# Altium schematic sheet Supervisor.SchDoc
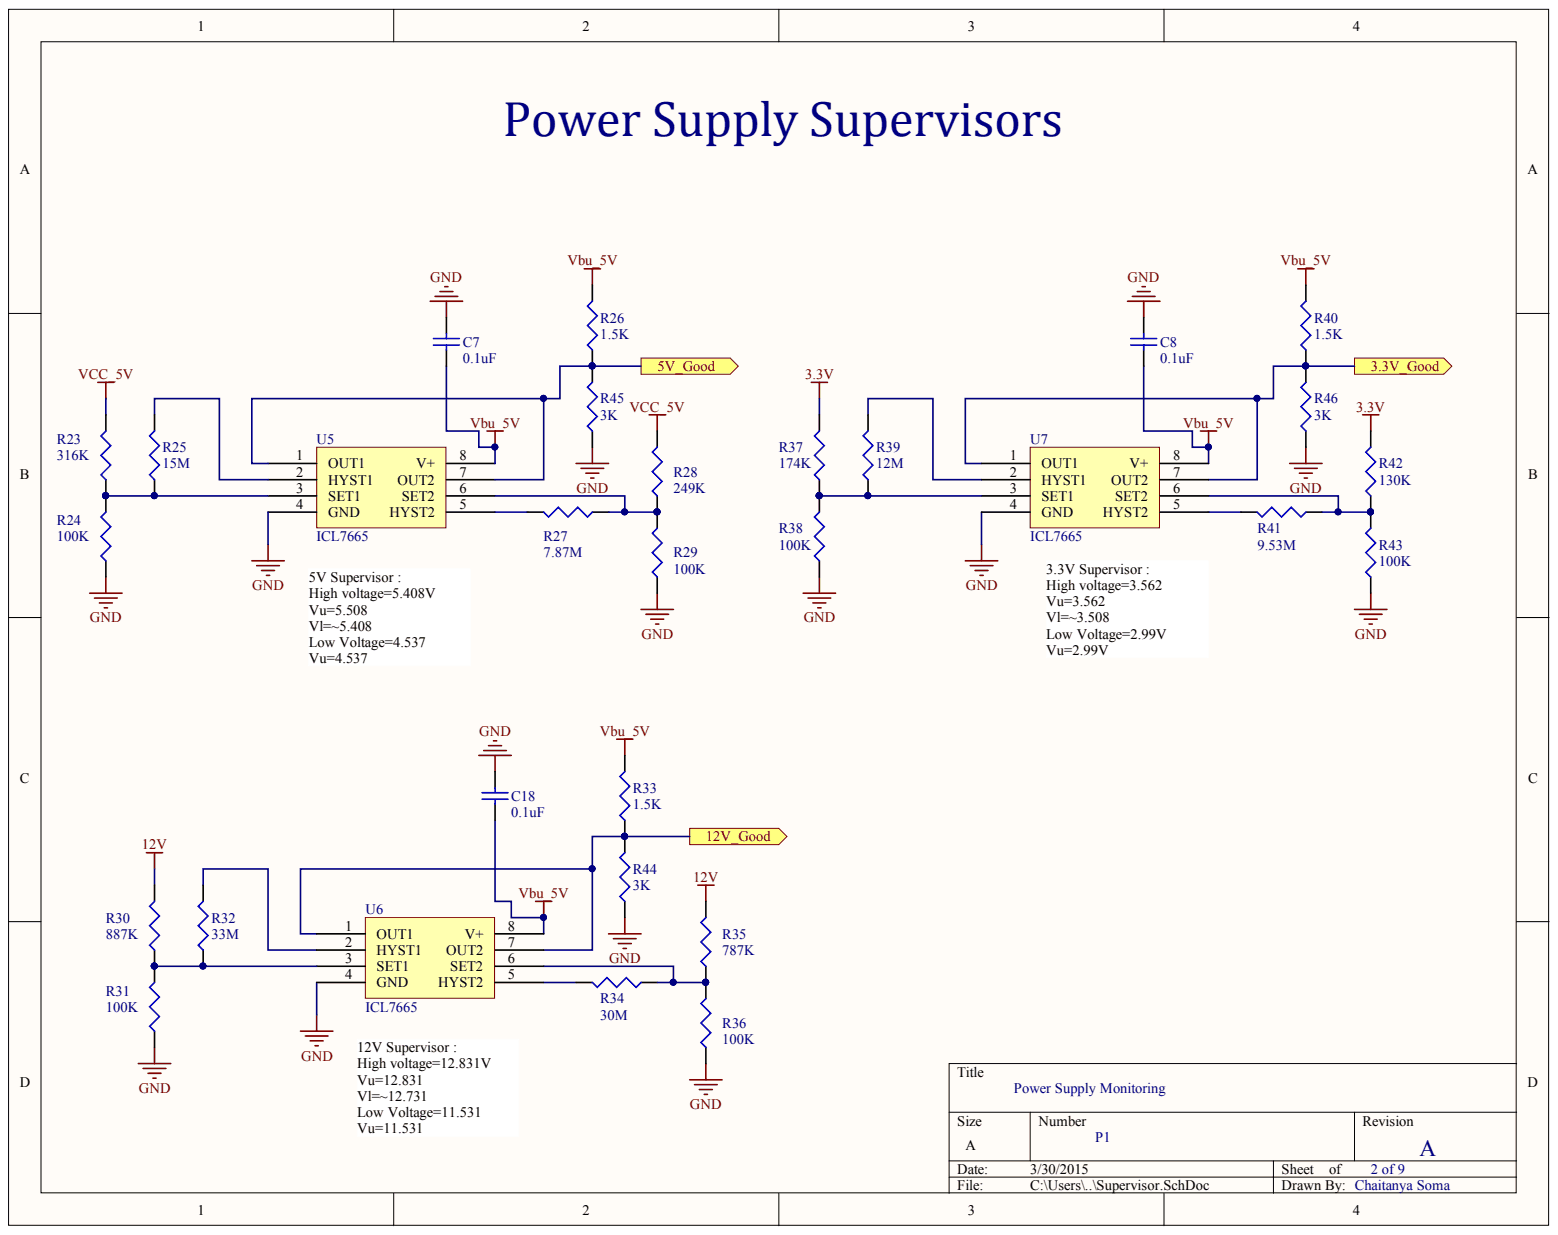
[diagram p0: Supervisor.SchDoc]

## Parts
C7  value 0.1uF  [1608[0603]]  (hidden comment: Cap Semi)
C8  value 0.1uF  [1608[0603]]  (hidden comment: Cap Semi)
C18  value 0.1uF  [1608[0603]]  (hidden comment: Cap Semi)
R23  value 316K  [J1-0603]  (hidden comment: Res3)
R24  value 100K  [J1-0603]  (hidden comment: Res3)
R25  value 15M  [J1-0603]  (hidden comment: Res3)
R26  value 1.5K  [J1-0603]  (hidden comment: Res3)
R27  value 7.87M  [J1-0603]  (hidden comment: Res3)
R28  value 249K  [J1-0603]  (hidden comment: Res3)
R29  value 100K  [J1-0603]  (hidden comment: Res3)
R30  value 887K  [J1-0603]  (hidden comment: Res3)
R31  value 100K  [J1-0603]  (hidden comment: Res3)
R32  value 33M  [J1-0603]  (hidden comment: Res3)
R33  value 1.5K  [J1-0603]  (hidden comment: Res3)
R34  value 30M  [J1-0603]  (hidden comment: Res3)
R35  value 787K  [J1-0603]  (hidden comment: Res3)
R36  value 100K  [J1-0603]  (hidden comment: Res3)
R37  value 174K  [J1-0603]  (hidden comment: Res3)
R38  value 100K  [J1-0603]  (hidden comment: Res3)
R39  value 12M  [J1-0603]  (hidden comment: Res3)
R40  value 1.5K  [J1-0603]  (hidden comment: Res3)
R41  value 9.53M  [J1-0603]  (hidden comment: Res3)
R42  value 130K  [J1-0603]  (hidden comment: Res3)
R43  value 100K  [J1-0603]  (hidden comment: Res3)
R44  value 3K  [J1-0603]  (hidden comment: Res3)
R45  value 3K  [J1-0603]  (hidden comment: Res3)
R46  value 3K  [J1-0603]  (hidden comment: Res3)
U5  ICL7665  [ICL7665ACSA+T]
U6  ICL7665  [ICL7665ACSA+T]
U7  ICL7665  [ICL7665ACSA+T]

## Ports
3.3V_GOOD, 5V_GOOD, 12V_GOOD

## Nets
3.3V: R37.2 R42.2
12V: R30.2 R35.2
GND: C7.1 C8.1 C18.1 R24.1 R29.1 R31.1 R36.1 R38.1 R43.1 R44.1 R45.1 R46.1 U5.4(GND) U6.4(GND) U7.4(GND)
VBU_5V: C7.2 C8.2 C18.2 R26.2 R33.2 R40.2 U5.8(V+) U6.8(V+) U7.8(V+)
VCC_5V: R23.2 R28.2
NetR23_1: R23.1 R24.2 R25.1 U5.3(SET1)
NetR25_2: R25.2 U5.2(HYST1)
NetR26_1: R26.1 R45.2 U5.1(OUT1) U5.7(OUT2) ; port 5V_GOOD
NetR27_1: R27.1 R28.1 R29.2 U5.6(SET2)
NetR27_2: R27.2 U5.5(HYST2)
NetR30_1: R30.1 R31.2 R32.1 U6.3(SET1)
NetR32_2: R32.2 U6.2(HYST1)
NetR33_1: R33.1 R44.2 U6.1(OUT1) U6.7(OUT2) ; port 12V_GOOD
NetR34_1: R34.1 R35.1 R36.2 U6.6(SET2)
NetR34_2: R34.2 U6.5(HYST2)
NetR37_1: R37.1 R38.2 R39.1 U7.3(SET1)
NetR39_2: R39.2 U7.2(HYST1)
NetR40_1: R40.1 R46.2 U7.1(OUT1) U7.7(OUT2) ; port 3.3V_GOOD
NetR41_1: R41.1 R42.1 R43.2 U7.6(SET2)
NetR41_2: R41.2 U7.5(HYST2)

## Library data of the parts
C7 C8 C18 = Cap Semi: Capacitor (Semiconductor SIM Model); Component Cost: 0.10000; Component Link Descriptions: CAP CER 0.1UF 25V 10% X7R 0603; Component Kind: Standard; Component Link URL: http://www.digikey.com/product-detail/en/GRM188R71E104KA01D/490-1524-1-ND/587865; Digikey PN: 490-1524-1-ND; LatestRevisionDate: 29-May-2009; LatestRevisionNote: IPC-7351 Footprint Added.; Library Name: Miscellaneous Devices.IntLib; PackageDescription: Chip Capacitor; PackageReference: C1206; Published: 8-Jun-2000; Signal Integrity: Cap Semi; Simulation: CAP; Publisher: Altium Limited
R23 = Res3: Resistor; Component Cost: 0.10000; Component Link Descriptions: RES SMD 316K OHM 1% 1/10W 0603; Component Kind: Standard; Component Link URL: http://www.digikey.com/product-detail/en/ERJ-3EKF3163V/P316KHCT-ND/198329; Digikey PN: P316KHCT-ND; LatestRevisionDate: 29-May-2009; LatestRevisionNote: IPC-7351 Footprint Added.; Library Name: Miscellaneous Devices.IntLib; PackageDescription: Chip Resistor; PackageReference: J1-0603; Published: 8-Jun-2000; Signal Integrity: Res; Simulation: RESISTOR; Publisher: Altium Limited
R24 R29 R31 R36 R38 R43 = Res3: Resistor; Component Cost: 0.10000; Component Link Descriptions: RES SMD 100K OHM 1% 1/10W 0603; Component Kind: Standard; Component Link URL: http://www.digikey.com/product-detail/en/ERJ-3EKF1003V/P100KHCT-ND/198110; Digikey PN: P100KHCT-ND; LatestRevisionDate: 29-May-2009; LatestRevisionNote: IPC-7351 Footprint Added.; Library Name: Miscellaneous Devices.IntLib; PackageDescription: Chip Resistor; PackageReference: J1-0603; Published: 8-Jun-2000; Signal Integrity: Res; Simulation: RESISTOR; Publisher: Altium Limited
R25 = Res3: Resistor; Component Cost: 0.41300; Component Link Descriptions: RES SMD 15M OHM 5% 1/10W 0603; Component Kind: Standard; Component Link URL: http://www.digikey.com/product-detail/en/CRCW060315M0JPEAHR/541-15MAHCT-ND/3231943; Digikey PN: 541-15MAHCT-ND; LatestRevisionDate: 29-May-2009; LatestRevisionNote: IPC-7351 Footprint Added.; Library Name: Miscellaneous Devices.IntLib; PackageDescription: Chip Resistor; PackageReference: J1-0603; Published: 8-Jun-2000; Signal Integrity: Res; Simulation: RESISTOR; Publisher: Altium Limited
R26 R33 R40 = Res3: Resistor; Component Cost: 0.10000; Component Link Descriptions: RES SMD 1.5K OHM 1% 1/10W 0603; Component Kind: Standard; Component Link URL: http://www.digikey.com/product-detail/en/RC1608F152CS/1276-4660-1-ND/3967632; Digikey PN: 1276-4660-1-ND; LatestRevisionDate: 29-May-2009; LatestRevisionNote: IPC-7351 Footprint Added.; Library Name: Miscellaneous Devices.IntLib; PackageDescription: Chip Resistor; PackageReference: J1-0603; Published: 8-Jun-2000; Signal Integrity: Res; Simulation: RESISTOR; Publisher: Altium Limited
R27 = Res3: Resistor; Component Cost: 0.10000; Component Link Descriptions: RES SMD 7.87M OHM 1% 1/10W 0603; Component Kind: Standard; Component Link URL: http://www.digikey.com/product-detail/en/RC1608F7874CS/1276-4983-1-ND/3967955; Digikey PN: 1276-4983-1-ND; LatestRevisionDate: 29-May-2009; LatestRevisionNote: IPC-7351 Footprint Added.; Library Name: Miscellaneous Devices.IntLib; PackageDescription: Chip Resistor; PackageReference: J1-0603; Published: 8-Jun-2000; Signal Integrity: Res; Simulation: RESISTOR; Publisher: Altium Limited
R28 = Res3: Resistor; Component Cost: 0.10000; Component Link Descriptions: RES SMD 249K OHM 1% 1/10W 0603; Component Kind: Standard; Component Link URL: http://www.digikey.com/product-detail/en/ERJ-3EKF2493V/P249KHCT-ND/198275; Digikey PN: P249KHCT-ND; LatestRevisionDate: 29-May-2009; LatestRevisionNote: IPC-7351 Footprint Added.; Library Name: Miscellaneous Devices.IntLib; PackageDescription: Chip Resistor; PackageReference: J1-0603; Published: 8-Jun-2000; Signal Integrity: Res; Simulation: RESISTOR; Publisher: Altium Limited
R30 = Res3: Resistor; Component Cost: 0.10000; Component Link Descriptions: RES SMD 887K OHM 1% 1/10W 0603; Component Kind: Standard; Component Link URL: http://www.digikey.com/product-detail/en/ERJ-3EKF8873V/P887KHCT-ND/198540; Digikey PN: P887KHCT-ND; LatestRevisionDate: 29-May-2009; LatestRevisionNote: IPC-7351 Footprint Added.; Library Name: Miscellaneous Devices.IntLib; PackageDescription: Chip Resistor; PackageReference: J1-0603; Published: 8-Jun-2000; Signal Integrity: Res; Simulation: RESISTOR; Publisher: Altium Limited
R32 = Res3: Resistor; Component Cost: 0.63000; Component Link Descriptions: RES SMD 33M OHM 5% 1/10W 0603; Component Kind: Standard; Component Link URL: http://www.digikey.com/product-detail/en/HMC0603JT33M0/HMC0603JT33M0CT-ND/4756233; Digikey PN: HMC0603JT33M0CT-ND; LatestRevisionDate: 29-May-2009; LatestRevisionNote: IPC-7351 Footprint Added.; Library Name: Miscellaneous Devices.IntLib; PackageDescription: Chip Resistor; PackageReference: J1-0603; Published: 8-Jun-2000; Signal Integrity: Res; Simulation: RESISTOR; Publisher: Altium Limited
R34 = Res3: Resistor; Component Cost: 0.63000; Component Link Descriptions: RES SMD 30M OHM 5% 1/10W 0603; Component Kind: Standard; Component Link URL: http://www.digikey.com/product-detail/en/HMC0603JT30M0/HMC0603JT30M0CT-ND/3902867; Digikey PN: HMC0603JT30M0CT-ND; LatestRevisionDate: 29-May-2009; LatestRevisionNote: IPC-7351 Footprint Added.; Library Name: Miscellaneous Devices.IntLib; PackageDescription: Chip Resistor; PackageReference: J1-0603; Published: 8-Jun-2000; Signal Integrity: Res; Simulation: RESISTOR; Publisher: Altium Limited
R35 = Res3: Resistor; Component Cost: 0.10000; Component Link Descriptions: RES SMD 787K OHM 1% 1/10W 0603; Component Kind: Standard; Component Link URL: http://www.digikey.com/product-detail/en/ERJ-3EKF7873V/P787KHCT-ND/198513; Digikey PN: P787KHCT-ND; LatestRevisionDate: 29-May-2009; LatestRevisionNote: IPC-7351 Footprint Added.; Library Name: Miscellaneous Devices.IntLib; PackageDescription: Chip Resistor; PackageReference: J1-0603; Published: 8-Jun-2000; Signal Integrity: Res; Simulation: RESISTOR; Publisher: Altium Limited
R37 = Res3: Resistor; Component Cost: 0.10000; Component Link Descriptions: RES SMD 174K OHM 1% 1/10W 0603; Component Kind: Standard; Component Link URL: http://www.digikey.com/product-detail/en/ERJ-3EKF1743V/P174KHCT-ND/198200; Digikey PN: P174KHCT-ND; LatestRevisionDate: 29-May-2009; LatestRevisionNote: IPC-7351 Footprint Added.; Library Name: Miscellaneous Devices.IntLib; PackageDescription: Chip Resistor; PackageReference: J1-0603; Published: 8-Jun-2000; Signal Integrity: Res; Simulation: RESISTOR; Publisher: Altium Limited
R39 = Res3: Resistor; Component Cost: 0.10000; Component Link Descriptions: RES SMD 12M OHM 10% 1/10W 0603; Component Kind: Standard; Component Link URL: http://www.digikey.com/product-detail/en/ERJ-3GEYK126V/P12MGCT-ND/282446; Digikey PN: P12MGCT-ND; LatestRevisionDate: 29-May-2009; LatestRevisionNote: IPC-7351 Footprint Added.; Library Name: Miscellaneous Devices.IntLib; PackageDescription: Chip Resistor; PackageReference: J1-0603; Published: 8-Jun-2000; Signal Integrity: Res; Simulation: RESISTOR; Publisher: Altium Limited
R41 = Res3: Resistor; Component Cost: 0.10000; Component Link Descriptions: RES SMD 9.53M OHM 1% 1/10W 0603; Component Kind: Standard; Component Link URL: http://www.digikey.com/product-detail/en/RC1608F9534CS/1276-4989-1-ND/3967961; Digikey PN: 1276-4989-1-ND; LatestRevisionDate: 29-May-2009; LatestRevisionNote: IPC-7351 Footprint Added.; Library Name: Miscellaneous Devices.IntLib; PackageDescription: Chip Resistor; PackageReference: J1-0603; Published: 8-Jun-2000; Signal Integrity: Res; Simulation: RESISTOR; Publisher: Altium Limited
R42 = Res3: Resistor; Component Cost: 0.10000; Component Link Descriptions: RES SMD 130K OHM 1% 1/10W 0603; Component Kind: Standard; Component Link URL: http://www.digikey.com/product-detail/en/ERJ-3EKF1303V/P130KHCT-ND/198154; Digikey PN: P130KHCT-ND; LatestRevisionDate: 29-May-2009; LatestRevisionNote: IPC-7351 Footprint Added.; Library Name: Miscellaneous Devices.IntLib; PackageDescription: Chip Resistor; PackageReference: J1-0603; Published: 8-Jun-2000; Signal Integrity: Res; Simulation: RESISTOR; Publisher: Altium Limited
R44-R46 = Res3: Resistor; Component Cost: 0.10000; Component Link Descriptions: RES SMD 3K OHM 1% 1/10W 0603; Component Kind: Standard; Component Link URL: http://www.digikey.com/product-detail/en/ERJ-3EKF3001V/P3.00KHCT-ND/1746757; Digikey PN: P3.00KHCT-ND; LatestRevisionDate: 29-May-2009; LatestRevisionNote: IPC-7351 Footprint Added.; Library Name: Miscellaneous Devices.IntLib; PackageDescription: Chip Resistor; PackageReference: J1-0603; Published: 8-Jun-2000; Signal Integrity: Res; Simulation: RESISTOR; Publisher: Altium Limited
U5-U7 = ICL7665: IC VOLT DETECT DUAL OVR/UND; Component Cost: 6.94000; Component Link Descriptions: IC VOLT DETEC DUAL OVR/UND 8SOIC; Component Kind: Standard; Component Link URL: http://www.digikey.com/product-detail/en/ICL7665ACSA%2B/ICL7665ACSA%2B-ND/947726; Digikey PN: ICL7665ACSA+-ND; Library Name: Power.SchLib
